# Altium schematic sheet power.SchDoc(power)
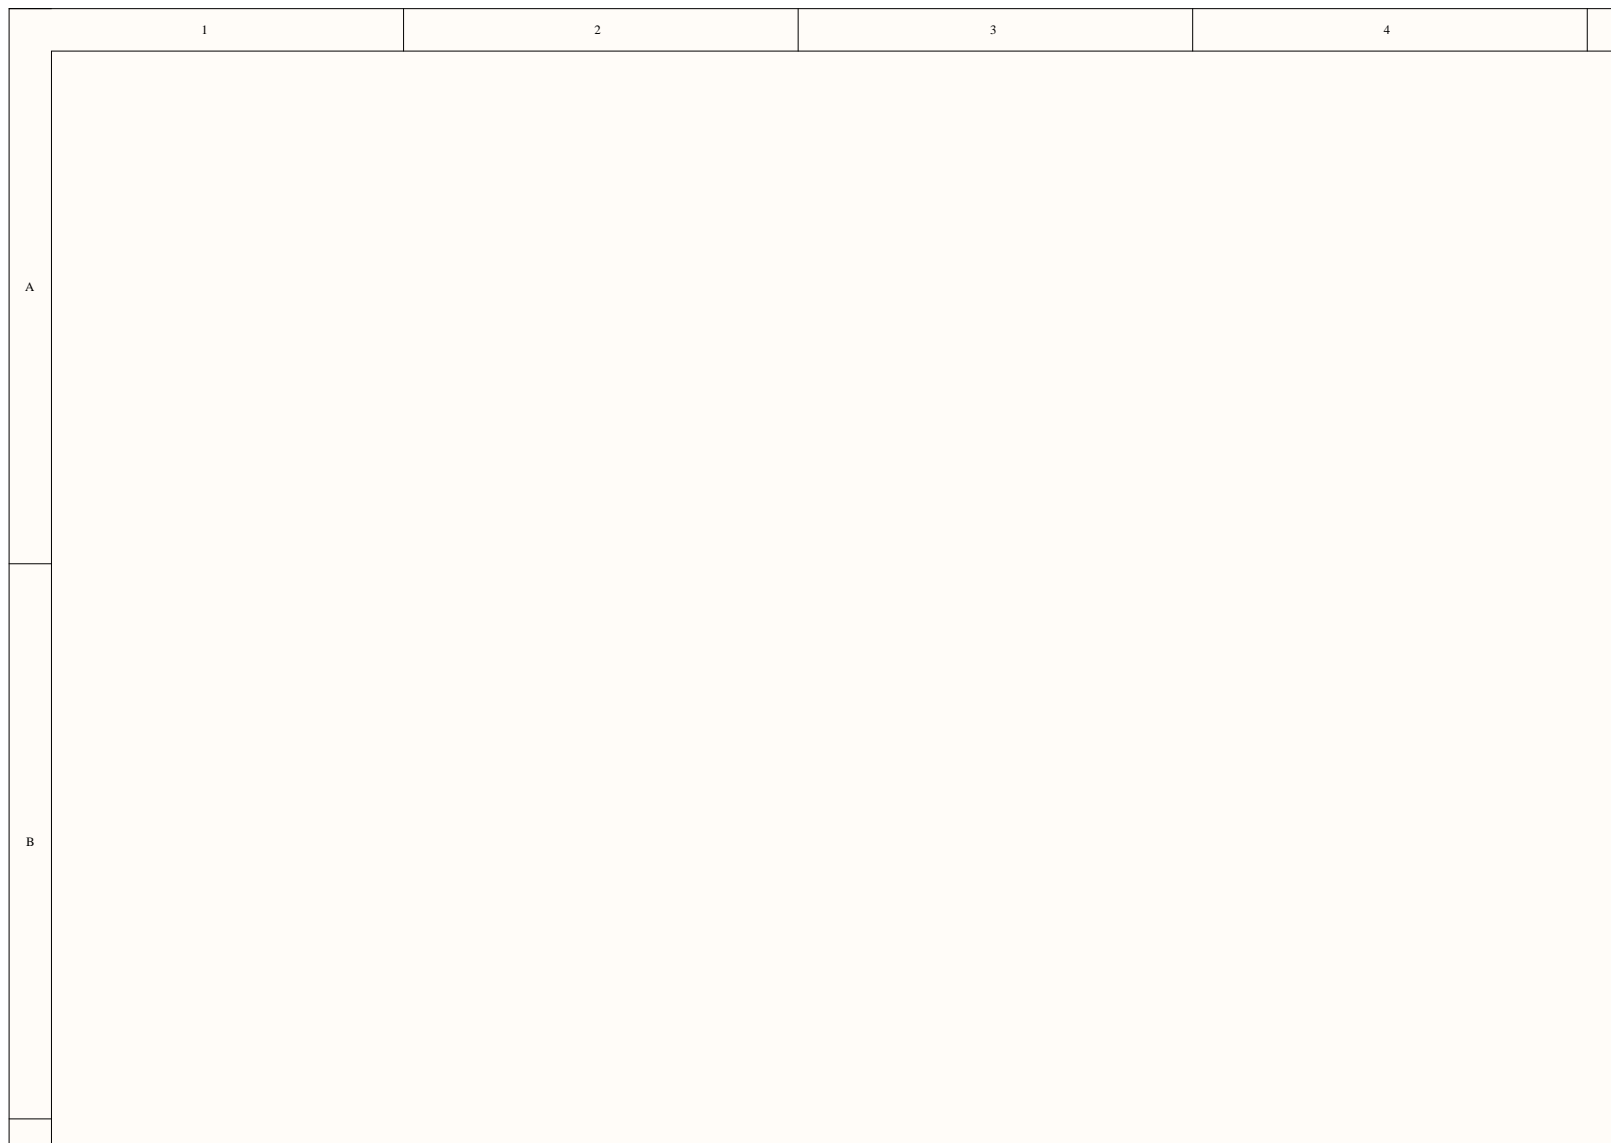
[diagram p0: power.SchDoc, top left]
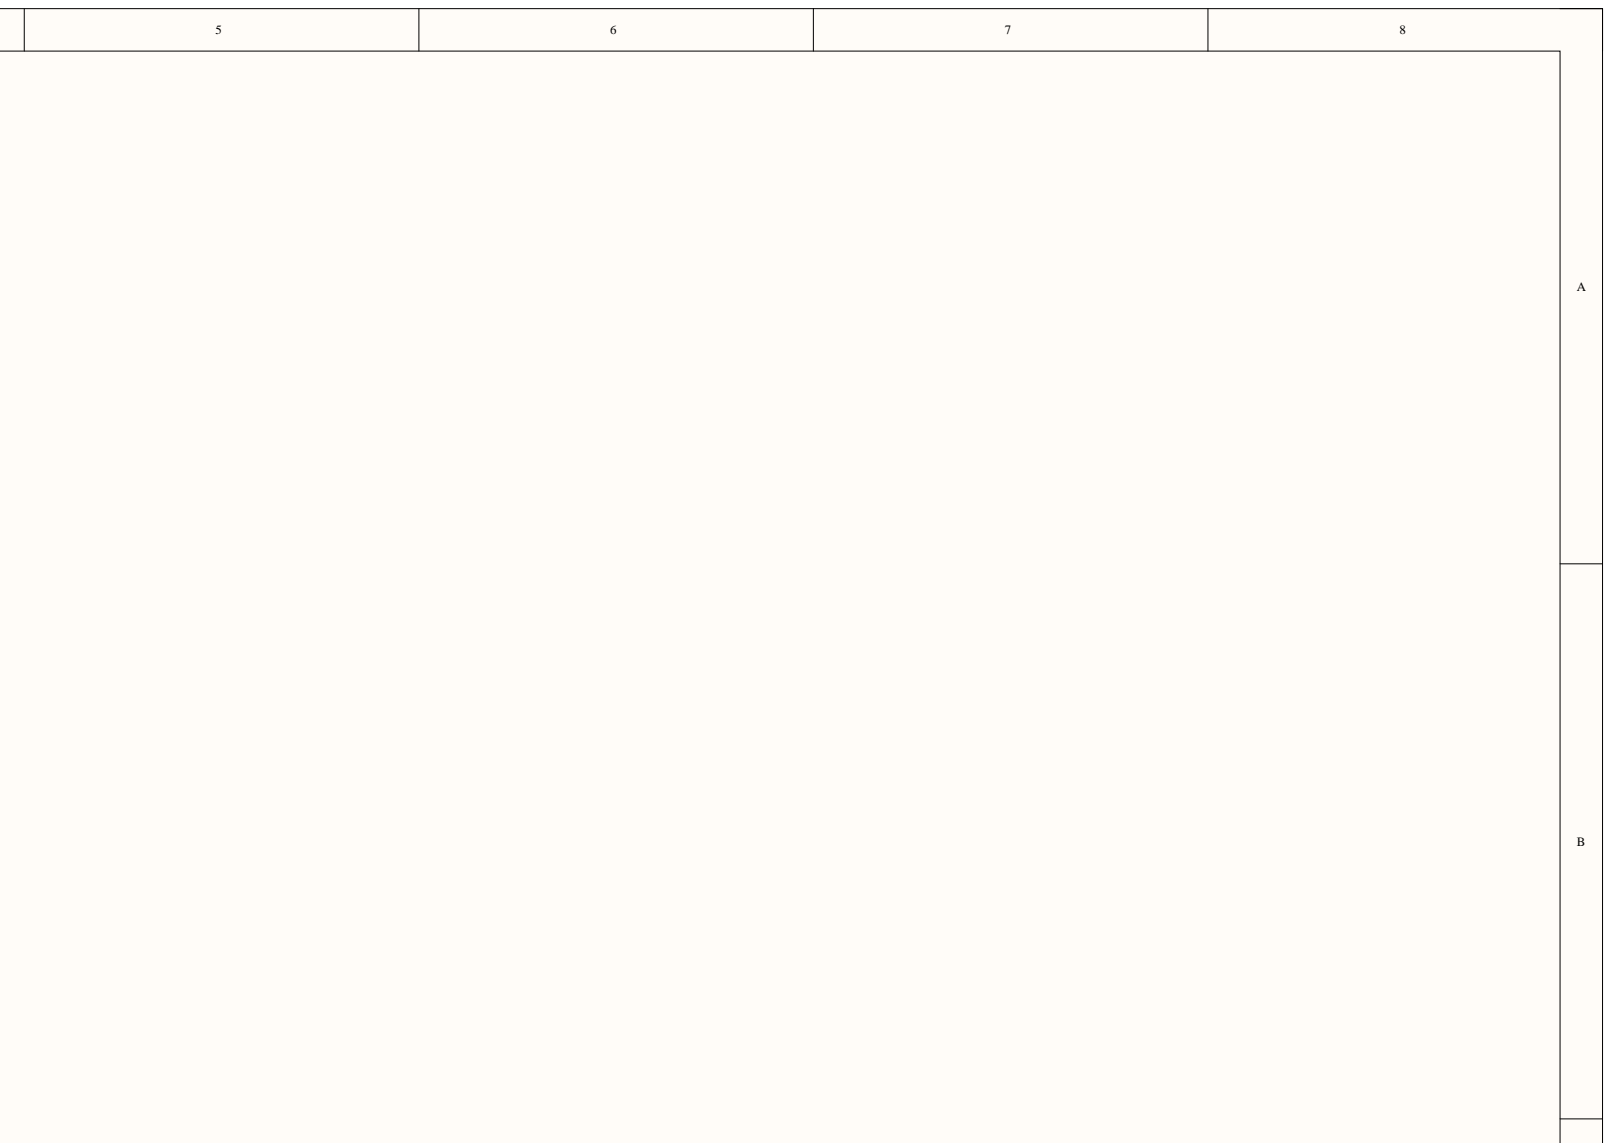
[diagram p1: power.SchDoc, top right]
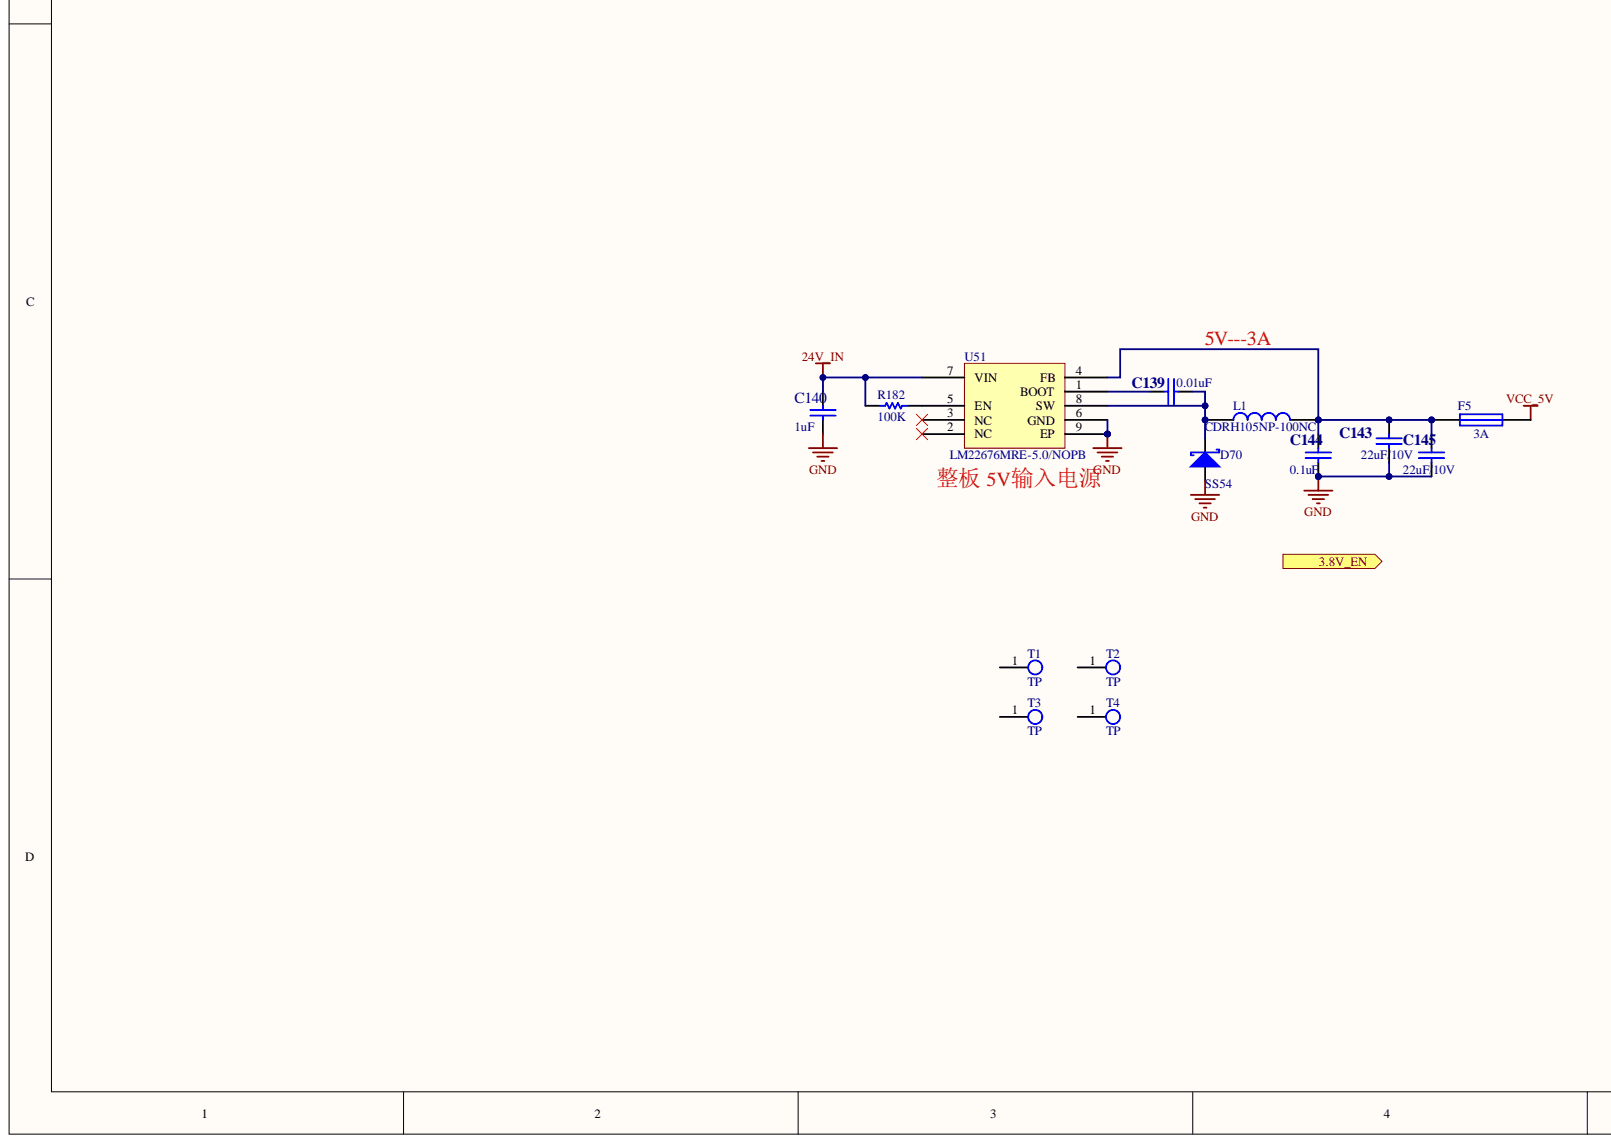
[diagram p2: power.SchDoc, bottom left]
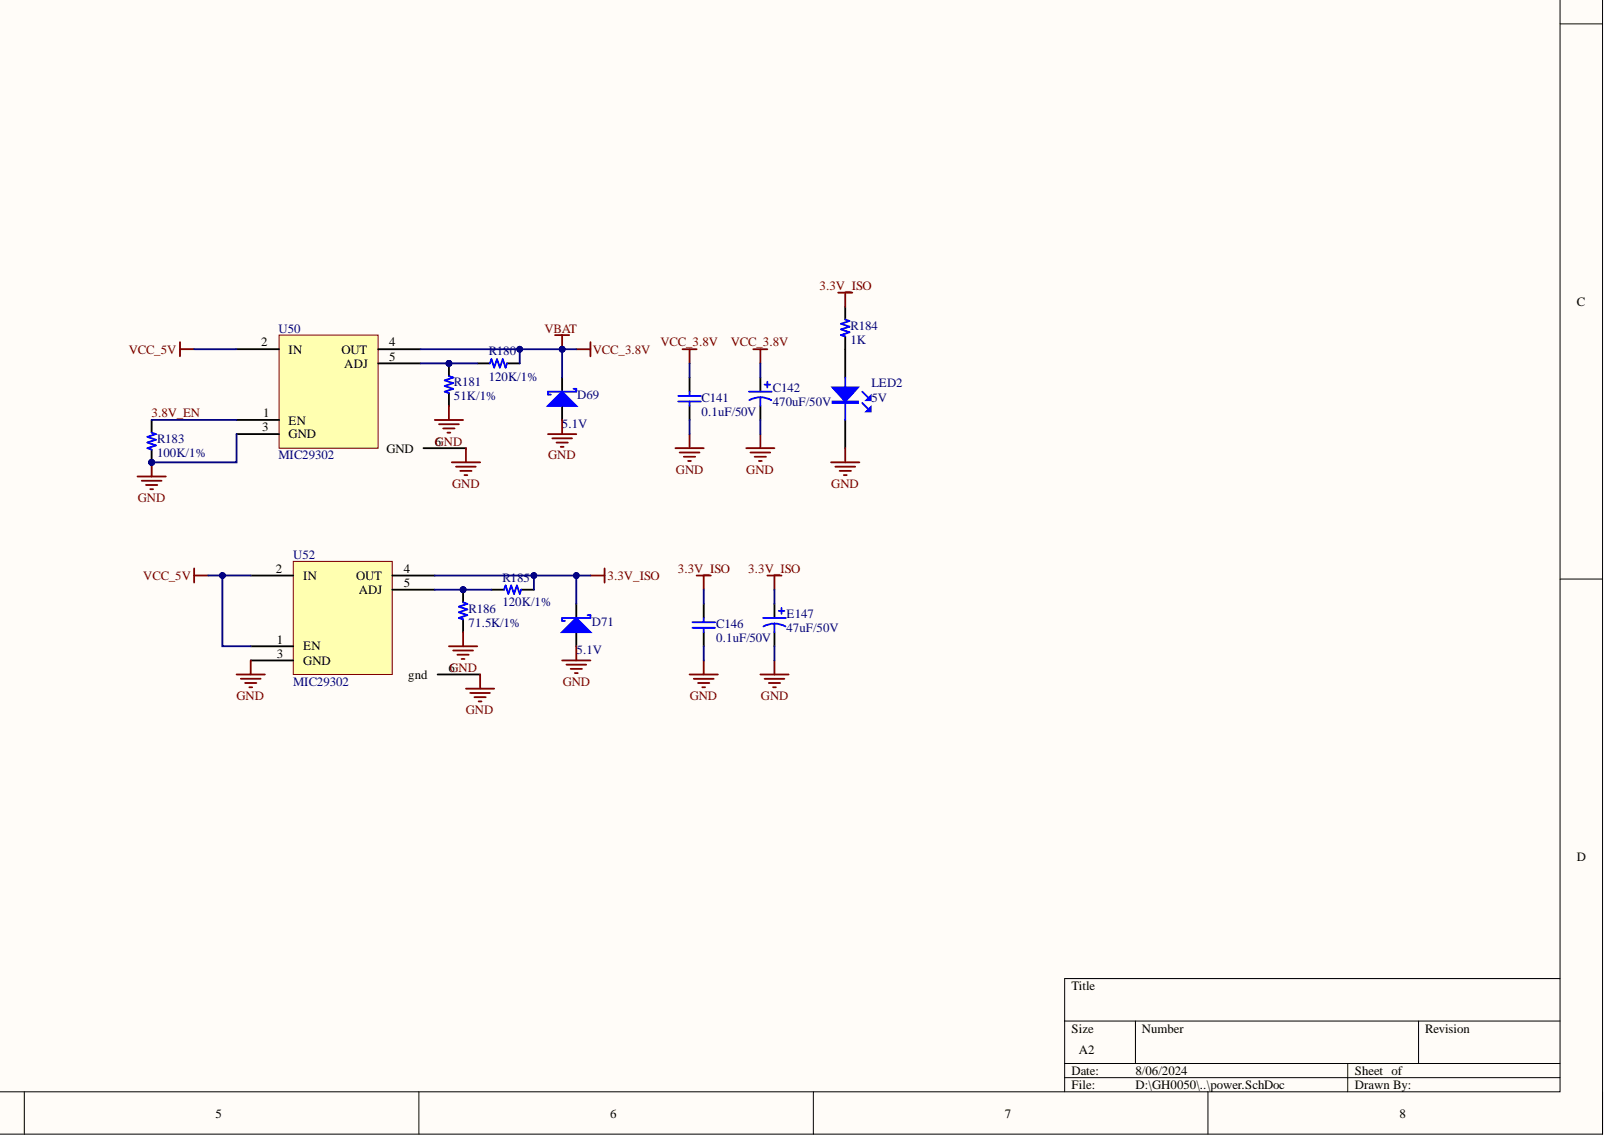
[diagram p3: power.SchDoc, bottom right]

## Parts
C139  0.01uF  [0603C]
C140  1uF  [0603C]
C141  0.1uF/50V  [0603C]
C142  470uF/50V  [Φ10×10]
C143  22uF/10V  [1210C]
C144  0.1uF  [0603C]
C145  22uF/10V  [1210C]
C146  0.1uF/50V  [0603C]
D69  5.1V  [SMA]
D70  SS54  [SMC]
D71  5.1V  [SMA]
E147  47uF/50V  [Φ10×10]
F5  3A  [1812-F]
L1  CDRH105NP-100NC  [CDRH105]
LED2  5V  [0603LED]
R180  120K/1%  [0603R]
R181  51K/1%  [0603R]
R182  100K  [0603R]
R183  100K/1%  [0603R]
R184  1K  [0603R]
R185  120K/1%  [0603R]
R186  71.5K/1%  [0603R]
T1  TP  [M3]
T2  TP  [M3]
T3  TP  [M3]
T4  TP  [M3]
U50  MIC29302  [TO228P1435X457-6N]
U51  LM22676MRE-5.0/NOPB  [SOP127P600X168_HS-8N]
U52  MIC29302  [TO228P1435X457-6N]

## Ports
3.8V_EN

## Nets
3.3V_ISO: C146.2 D71.2 E147.1 R184.2 R185.1 U52.4(OUT)
24V_IN: C140.2 R182.2 U51.7(VIN)
GND: C140.1 C141.1 C142.2 C143.2 C144.2 C145.2 C146.1 D69.1 D70.1 D71.1 E147.2 LED2.2 R181.1 R183.1 R186.1 U50.3(GND) U50.6(GND) U51.6(GND) U51.9(EP) U52.3(GND) U52.6(gnd)
VBAT: C141.2 C142.1 D69.2 R180.1 U50.4(OUT)
VCC_5V: F5.2 U50.2(IN) U52.1(EN) U52.2(IN)
3.8V_EN: R183.2 U50.1(EN)
NetC139_1: C139.1 D70.2 L1.1 U51.8(SW)
NetC139_2: C139.2 U51.1(BOOT)
NetC143_1: C143.1 C144.1 C145.1 F5.1 L1.2 U51.4(FB)
NetLED2_1: LED2.1 R184.1
NetR180_2: R180.2 R181.2 U50.5(ADJ)
NetR182_1: R182.1 U51.5(EN)
NetR185_2: R185.2 R186.2 U52.5(ADJ)
N00069: ; port 3.8V_EN

Not connected: T1 1; T2 1; T3 1; T4 1; U51 2(NC) 3(NC)

## Library data of the parts
C139 C140 C143-C145 = CAP: Capacitor; Component Kind: Standard
C141 C146 = Cap: Capacitor; Value: 22nF; Component Kind: Standard; LatestRevisionDate: 17-Jul-2002; LatestRevisionNote: Re-released for DXP Platform.; Library Name: Miscellaneous Devices.IntLib; PackageDescription: Radial Cap, Thru-Hole; 2 Leads; 0.3 in Pin Spacing; PackageReference: RAD-0.3; Published: 8-Jun-2000; Signal Integrity: Cap; Simulation: CAP; Publisher: Altium Limited
C142 E147 = Cap Pol1: Polarized Capacitor (Radial); Value: 100pF; Component Kind: Standard; LatestRevisionDate: 17-Jul-2002; LatestRevisionNote: Re-released for DXP Platform.; Library Name: Miscellaneous Devices.IntLib; PackageReference: RB7.6-15; Published: 8-Jun-2000; Signal Integrity: Cap Pol; Simulation: CAP; Publisher: Altium Limited
D69-D71 = DIODE S: Schottky Diode; Component Kind: Standard
F5 = Fuse 1: Fuse; Component Kind: Standard; LatestRevisionDate: 17-Jul-2002; LatestRevisionNote: Re-released for DXP Platform.; Library Name: Miscellaneous Devices.IntLib; PackageDescription: Thru-Hole; 2 Leads; PackageReference: PIN-W2/E2.8; Published: 8-Jun-2000; Simulation: FUSE; Publisher: Altium Limited
L1 = INDUCTOR: Component Kind: Standard
LED2 = LED: Component Kind: Standard
R180 R181 R183-R186 = R: Component Kind: Standard; Description: R; Library Name: JRJ_1.SCHLIB
R182 = Res1: Resistor; Component Kind: Standard; LatestRevisionDate: 17-Jul-2002; LatestRevisionNote: Re-released for DXP Platform.; Library Name: Miscellaneous Devices.IntLib; PackageReference: AXIAL-0.3; Published: 8-Jun-2000; Signal Integrity: Res; Simulation: RESISTOR; Publisher: Altium Limited
U51 = LM22676MR-5.0: Component Kind: Standard; Library Name: Schlib1.SchLib
T1-T4 = TP: Component Kind: Standard; Library Name: Schlib_20110825.schlib
U50 U52 = MIC29302: Component Kind: Standard; Library Name: power.SCHLIB
